# Altium schematic sheet Amp_op4.SchDoc(Amp_op4)
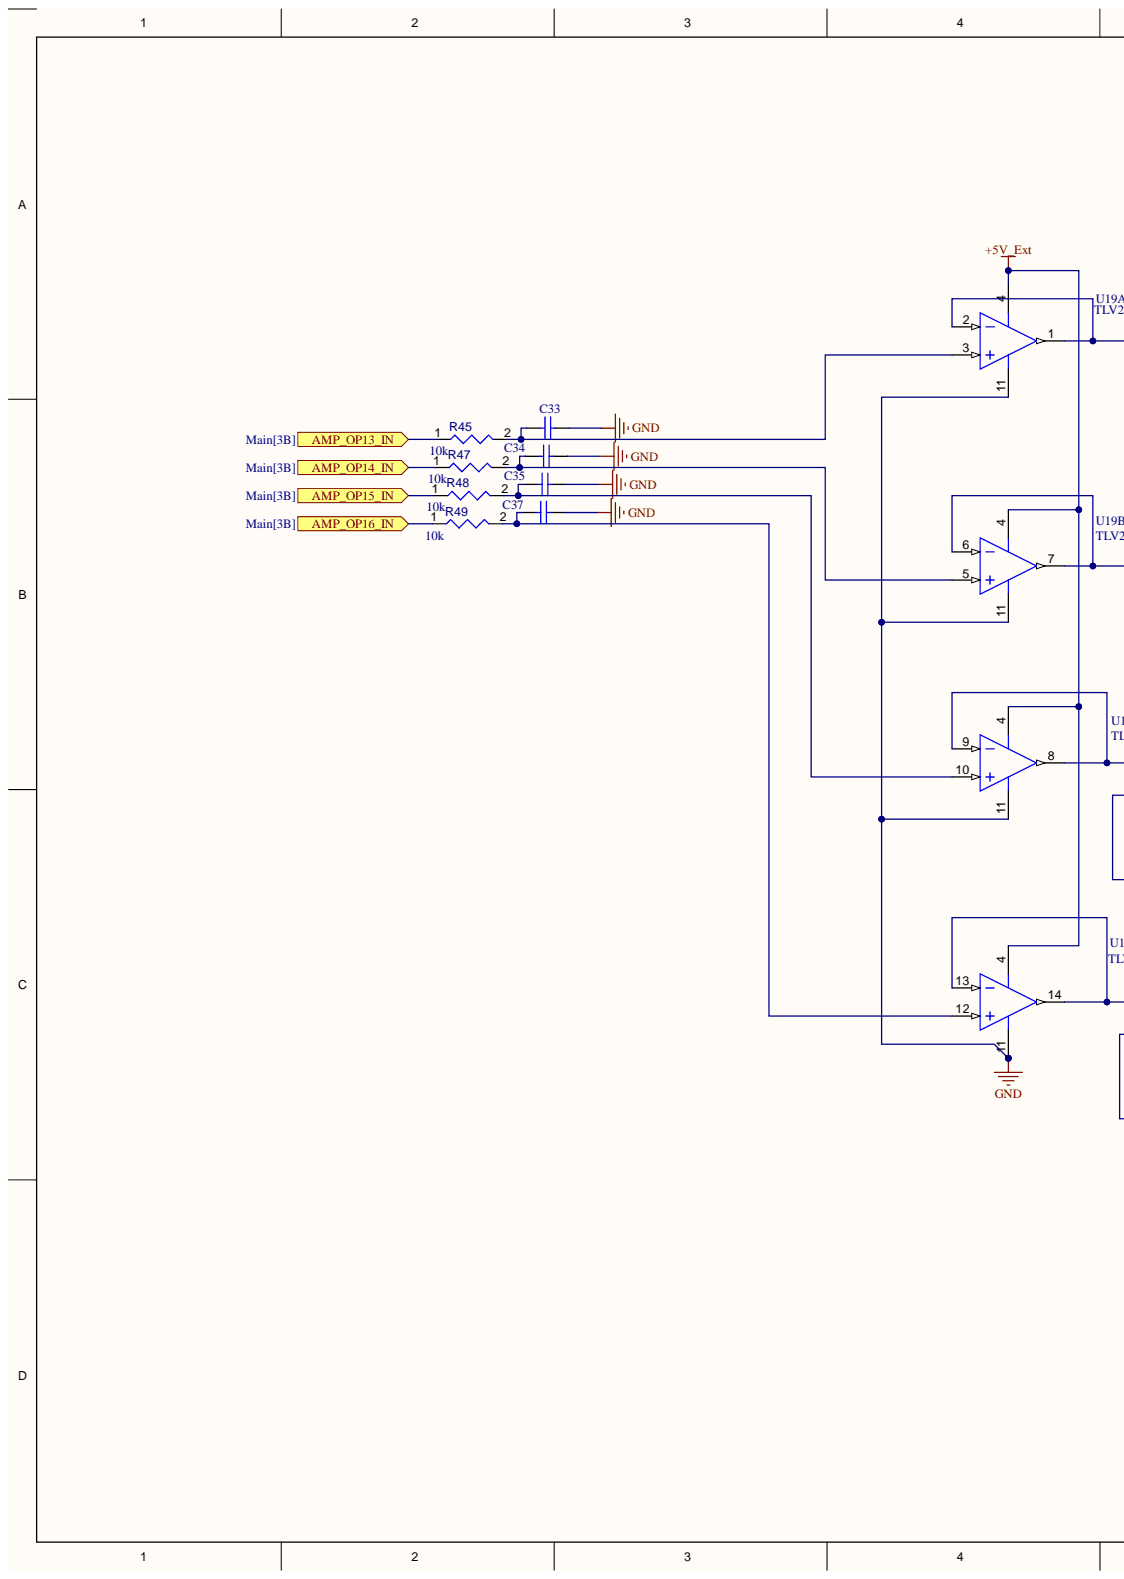
[diagram p0: Amp_op4.SchDoc, left]
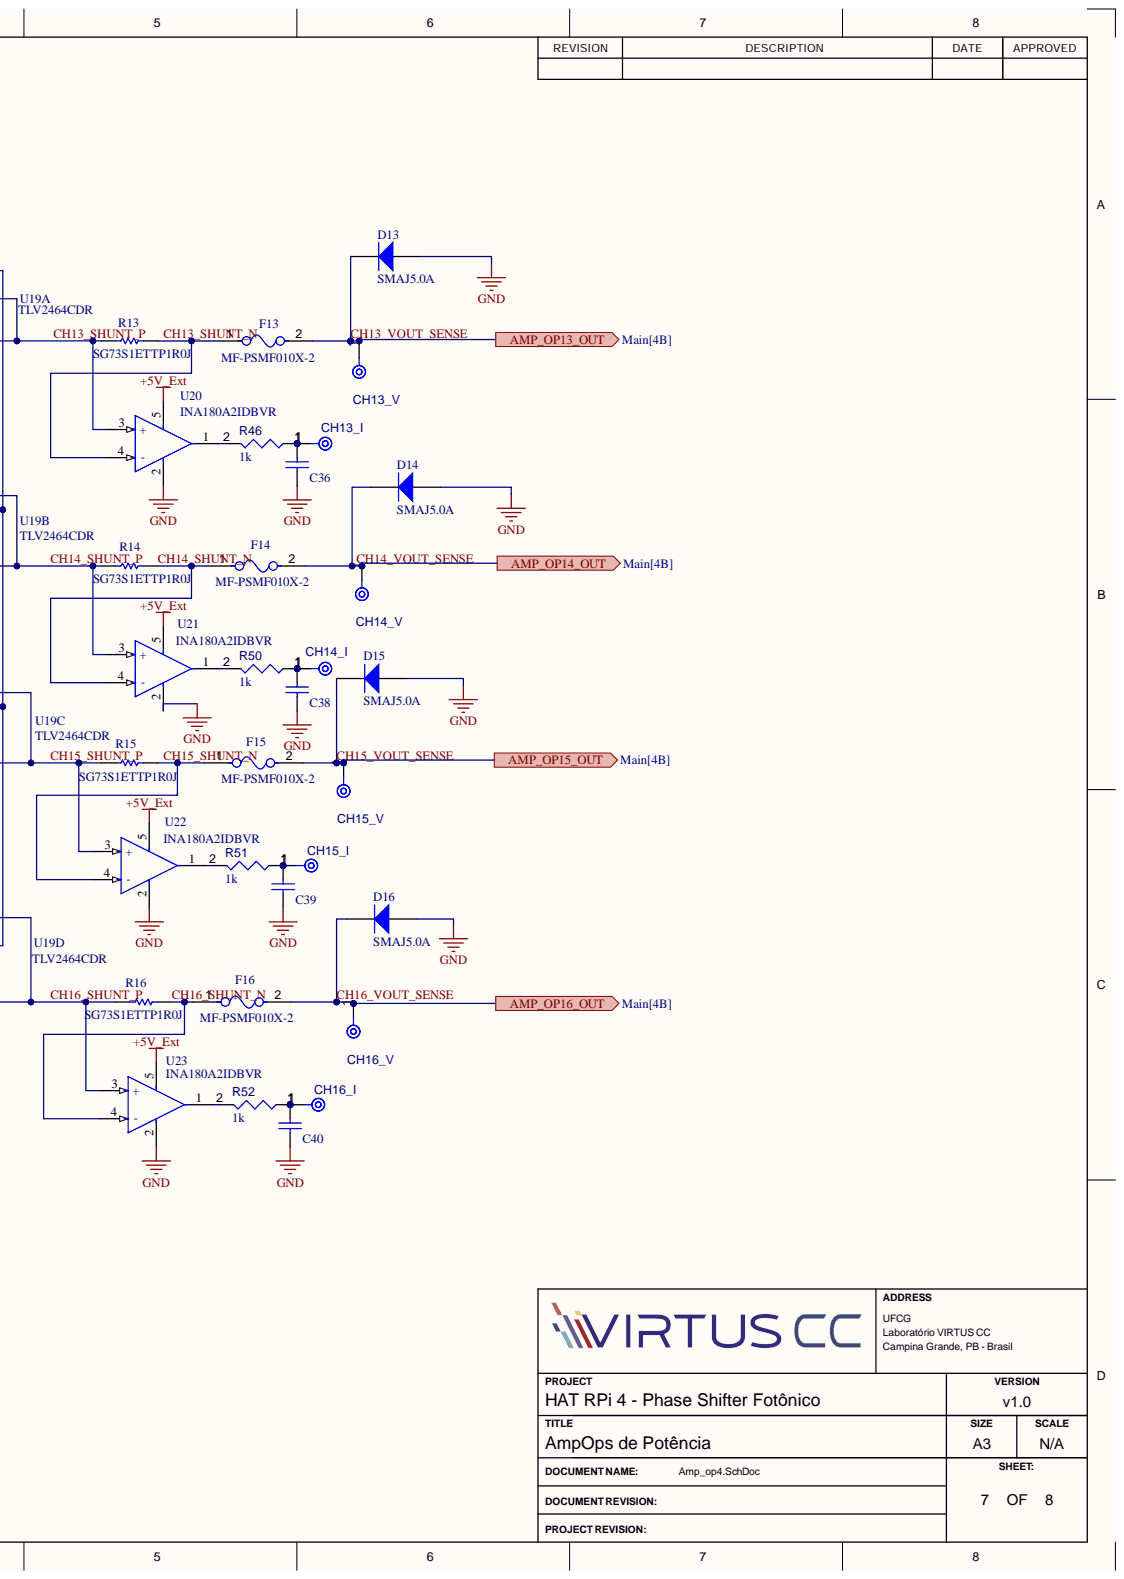
[diagram p1: Amp_op4.SchDoc, right]

## Parts
C33  VJ0603Y104MXJCW1BC  [CAPC1608X95X40NL15T15]  (value not drawn)
C34  VJ0603Y104MXJCW1BC  [CAPC1608X95X40NL15T15]  (value not drawn)
C35  VJ0603Y104MXJCW1BC  [CAPC1608X95X40NL15T15]  (value not drawn)
C36  VJ0603Y104MXJCW1BC  [CAPC1608X95X40NL15T15]  (value not drawn)
C37  VJ0603Y104MXJCW1BC  [CAPC1608X95X40NL15T15]  (value not drawn)
C38  VJ0603Y104MXJCW1BC  [CAPC1608X95X40NL15T15]  (value not drawn)
C39  VJ0603Y104MXJCW1BC  [CAPC1608X95X40NL15T15]  (value not drawn)
C40  VJ0603Y104MXJCW1BC  [CAPC1608X95X40NL15T15]  (value not drawn)
CH13_I  TPAD6R  [TP06R]  (value not drawn)  (no BOM)
CH13_V  TPAD6R  [TP06R]  (value not drawn)  (no BOM)
CH14_I  TPAD6R  [TP06R]  (value not drawn)  (no BOM)
CH14_V  TPAD6R  [TP06R]  (value not drawn)  (no BOM)
CH15_I  TPAD6R  [TP06R]  (value not drawn)  (no BOM)
CH15_V  TPAD6R  [TP06R]  (value not drawn)  (no BOM)
CH16_I  TPAD6R  [TP06R]  (value not drawn)  (no BOM)
CH16_V  TPAD6R  [TP06R]  (value not drawn)  (no BOM)
D13  SMAJ5.0A  [BOUR-SMA-2_V]
D14  SMAJ5.0A  [BOUR-SMA-2_V]
D15  SMAJ5.0A  [BOUR-SMA-2_V]
D16  SMAJ5.0A  [BOUR-SMA-2_V]
F13  MF-PSMF010X-2  [FP-MF-PSMF-MFG]
F14  MF-PSMF010X-2  [FP-MF-PSMF-MFG]
F15  MF-PSMF010X-2  [FP-MF-PSMF-MFG]
F16  MF-PSMF010X-2  [FP-MF-PSMF-MFG]
R13  SG73S1ETTP1R0J  [RESC1005X40X25LL10T10]
R14  SG73S1ETTP1R0J  [RESC1005X40X25LL10T10]
R15  SG73S1ETTP1R0J  [RESC1005X40X25LL10T10]
R16  SG73S1ETTP1R0J  [RESC1005X40X25LL10T10]
R45  value 10k  [RESC0603(1608)_L]  (hidden comment: Resistor 10k +/-1% 0603 100 mW)
R46  value 1k  [RESC0603(1608)_L]  (hidden comment: Resistor 1k +/-1% 0603 100 mW)
R47  value 10k  [RESC0603(1608)_L]  (hidden comment: Resistor 10k +/-1% 0603 100 mW)
R48  value 10k  [RESC0603(1608)_L]  (hidden comment: Resistor 10k +/-1% 0603 100 mW)
R49  value 10k  [RESC0603(1608)_L]  (hidden comment: Resistor 10k +/-1% 0603 100 mW)
R50  value 1k  [RESC0603(1608)_L]  (hidden comment: Resistor 1k +/-1% 0603 100 mW)
R51  value 1k  [RESC0603(1608)_L]  (hidden comment: Resistor 1k +/-1% 0603 100 mW)
R52  value 1k  [RESC0603(1608)_L]  (hidden comment: Resistor 1k +/-1% 0603 100 mW)
U19  TLV2464CDR  [D0014A_N]  (parts A,B,C,D)
U20  INA180A2IDBVR  [FP-DBV0005A-MFG]
U21  INA180A2IDBVR  [FP-DBV0005A-MFG]
U22  INA180A2IDBVR  [FP-DBV0005A-MFG]
U23  INA180A2IDBVR  [FP-DBV0005A-MFG]

## Ports
AMP_OP13_IN, AMP_OP13_OUT, AMP_OP14_IN, AMP_OP14_OUT, AMP_OP15_IN, AMP_OP15_OUT, AMP_OP16_IN, AMP_OP16_OUT

## Nets
+5V_Ext: U19.4 U20.5 U21.5 U22.5 U23.5
GND: C33.2 C34.2 C35.2 C36.2 C37.2 C38.2 C39.2 C40.2 D13.1 D14.1 D15.1 D16.1 U19.11 U20.2 U21.2 U22.2 U23.2
AMP_OP13_IN: R45.1 ; port AMP_OP13_IN
AMP_OP14_IN: R47.1 ; port AMP_OP14_IN
AMP_OP15_IN: R48.1 ; port AMP_OP15_IN
AMP_OP16_IN: R49.1 ; port AMP_OP16_IN
CH13_SHUNT_N: F13.1 R13.2 U20.4(-)
CH13_SHUNT_P: R13.1 U19.1 U19.2 U20.3(+)
CH13_VOUT_SENSE: CH13_V.1 D13.2 F13.2 ; port AMP_OP13_OUT
CH14_SHUNT_N: F14.1 R14.2 U21.4(-)
CH14_SHUNT_P: R14.1 U19.6 U19.7 U21.3(+)
CH14_VOUT_SENSE: CH14_V.1 D14.2 F14.2 ; port AMP_OP14_OUT
CH15_SHUNT_N: F15.1 R15.2 U22.4(-)
CH15_SHUNT_P: R15.1 U19.8 U19.9 U22.3(+)
CH15_VOUT_SENSE: CH15_V.1 D15.2 F15.2 ; port AMP_OP15_OUT
CH16_SHUNT_N: F16.1 R16.2 U23.4(-)
CH16_SHUNT_P: R16.1 U19.13 U19.14 U23.3(+)
CH16_VOUT_SENSE: CH16_V.1 D16.2 F16.2 ; port AMP_OP16_OUT
NetC33_1: C33.1 R45.2 U19.3
NetC34_1: C34.1 R47.2 U19.5
NetC35_1: C35.1 R48.2 U19.10
NetC36_1: C36.1 CH13_I.1 R46.1
NetC37_1: C37.1 R49.2 U19.12
NetC38_1: C38.1 CH14_I.1 R50.1
NetC39_1: C39.1 CH15_I.1 R51.1
NetC40_1: C40.1 CH16_I.1 R52.1
NetR46_2: R46.2 U20.1
NetR50_2: R50.2 U21.1
NetR51_2: R51.2 U22.1
NetR52_2: R52.2 U23.1

## Library data of the parts
C33-C40 = CMP-2006-00058-1: Manufacturer: Vitramon; Component Kind: Standard; Library Name: Altium Content Vault; Part Number: VJ0603Y104MXJCW1BC
CH13_I CH13_V CH14_I CH14_V CH15_I CH15_V CH16_I CH16_V = CMP-00028-00002-2: 0.6mm round; Component Type: Mechanical; Pins: 1; Library Name: PCEIOT.SCHLIB
D13-D16 = CMP-2000-07090-1: SMAJ Transient Voltage Suppressor Diode Series, 3.5 V, -55 to 150 degC, 2-Pin SMA, RoHS, Tape and Reel; Manufacturer: Bourns; Catalog Drawings: SMAJSeriesFootprintSMAJ,SMBJSeries; Current - Peak Pulse (10/1000µs): 43.5A; EDA / CAD Models: DownloadfromAcceleratedDesigns; Featured Product: SMAJ/SMBJ/SMCJ/SMLJDiscreteTVSDiodes; Online Catalog: SMAJSeries; Other Names: SMAJ5.0ABDKR; Power - Peak Pulse: 400W; Power Line Protection: No; Type: Zener; Unidirectional Channels: 1; Voltage - Breakdown (Min): 6.4V; Voltage - Clamping (Max) @ Ipp: 9.2V; Voltage - Reverse Standoff (Typ): 5V; Applications: GeneralPurpose; Bidirectional Channels: -; Capacitance @ Frequency: -; Category: CircuitProtection; Component Kind: Standard; Datasheet URL: https://datasheet.ciiva.com/25624/0900766b8112213b-25624680.pdf; Datasheet Version: 08/2012; Family: TVS-Diodes; Library Name: Altium Content Vault; Manufacturer URL: http://www.bourns.com/; Mounting Technology: SurfaceMount; Mounting Type: SurfaceMount; Operating Temperature: -55°C~150°C(TJ); Package / Case: DO-214AC,SMA; Package Description: 2-Pin Surface Mount Device, Body 4.315 x 2.605 mm; Package Reference: SMA-2; Packaging: Digi-Reel®; RoHS Information: SMAJSeriesMaterialDeclaration; Series: SMAJ; Standard Package: 1; Supplier Device Package: SMA(DO-214AC)
F13-F16 = CMP-07400-000017-1: PTC RESET FUSE 15V 100MA 0805; Manufacturer: Bourns Inc.; Current Rating: 40A; Hold Current: 0.1A; Resistance: 1-7.5Ω; Trip Current: 0.3A; Trip Time: 1.5s; Value: 1-7.5Ω; Ambient Temperature Range High: +85°C; Ambient Temperature Range Low: -40°C; Automotive: No; Category: Fuse; Component Kind: Standard; ComponentLink2Description: Datasheet; ComponentLink2URL: https://www.bourns.com/docs/Product-Datasheets/mfpsmf.pdf; Device Class L1: Circuit Protection; Device Class L2: PTC Resettable Fuses; Device Class L3: unset; Digikey Description: PTC RESET FUSE 15V 100MA 0805; Height: 0.85mm; Ipc Land Pattern Name: CAPC215135X67; Lead Free: Yes; Library Name: Altium Content Vault; Manufacturer Part Number: MF-PSMF010X-2; Mouser Description: Resettable Fuses - PPTC 0.10A 15V 1ohm; Octopart: https://octopart.com/mf-psmf010x-2-bourns-7483441; Package: MF-PSMF; RoHS: TRUE; Temperature: -40°C to +85°C; Temperature Range High: +85°C; Temperature Range Low: -40°C; Voltage Rating: 15V
R13-R16 = CMP-2002-04124-1: Manufacturer: KOA Speer; Component Kind: Standard; Library Name: Altium Content Vault; Part Number: SG73S1ETTP1R0J
R45 R47-R49 = CMP-009-00017-7: Chip Resistor, 10 KOhm, +/- 1%, 01 W, -55 to 155 degC, 0603 (1608 Metric); Manufacturer: Vishay; Manufacturer Part Number: CRCW060310K0FKEA; Power: 100mW; Voltage Rating: 50V; Case/Package: 0603; Component Kind: Standard; Component Type: Resistors; Max Operating Temperature: 155°C; Min Operating Temperature: -55°C; Mounting Technology: SM; Pins: 2; RoHS Compliant: True; Tolerance: 1%; Library Name: PCEIOT.SCHLIB
R46 R50-R52 = CMP-009-00014-6: Chip Resistor, 1 KOhm, +/-1%, 0.1 W, -55 to 155 degC, 0603 (1608 Metric); Manufacturer: Yageo; Manufacturer Part Number: RC0603FR-131KL; Power: 100mW; Tolerance: 1%; Voltage Rating: 50V; Case/Package: 0603; Component Kind: Standard; Component Type: Resistors; Max Operating Temperature: 155°C; Min Operating Temperature: -55°C; Mounting Technology: SM; Pins: 2; RoHS Compliant: True; Library Name: PCEIOT.SCHLIB
U19 = CMP-0271-00006-3: Quad Low Power, Rail-to-Rail Input / Output Operational Amplifier, 2.7 to 6 V, 0 to 70 degC, 14-pin SOIC (D14), Green (RoHS & no Sb/Br); Manufacturer: Texas Instruments; CMRR(Min)(dB): 66; IIB(Max)(pA): 14000; Iq per channel(Max)(mA): 0.575; Number of Channels: 4; Offset Drift(Typ)(uV/C): 2; Slew Rate(Typ)(V/us): 1.6; Sub Family: High Output Current Amplifier (>=50mA), Low Supply Voltage Amplifier (<=2.7V), Precision Amplifier; Total Supply Voltage(Max)(+5V is 5, +/-5V is 10): 6; Total Supply Voltage(Min)(+5V is 5, +/-5V is 10): 2.7; Vn at 1kHz(Typ)(nV/rtHz): 11; Code_JEDEC: MS-012; Component Kind: Standard; ComponentLink1Description: Manufacturer URL; ComponentLink1URL: http://www.ti.com/; ComponentLink2Description: Datasheet; ComponentLink2URL: http://www.ti.com/general/docs/lit/getliterature.tsp?genericPartNumber=TLV2464&fileType=pdf; ComponentLink3Description: Package Specification; ComponentLink3URL: http://www.ti.com/lit/ml/mpds177g/mpds177g.pdf; DatasheetVersion: SLOS220J; Eco-Plan: Green (RoHS & no Sb/Br); Library Name: Altium Content Vault; Mounting Technology: Surface Mount; PackageDescription: 14-Pin Plastic Small Outline, Body 8.65 x 3.9 mm, Pitch 1.27 mm; PackageReference: D0014A; PackageVersion: 4040047-5/M, 06/2011; PartNumber: TLV2464CDR; Vio (25C)(Max)(mV): 2
U20-U23 = CMP-04895-000287-1: IC CURR SENSE 1 CIRCUIT SOT23-5; -3db Bandwidth: 350kHz; Input Bias Current: 100nA; Input Offset Voltage (Vos): 100uV; Number of Circuits: 1; Operating Supply Current: 260uA; Slew Rate: 2V/µs; Case/Package: SOT-23-5; Component Kind: Standard; Library Name: Altium Content Vault; Max Operating Temperature: 125°C; Min Operating Temperature: -40°C; Mount: Surface Mount; Packaging: Tape and Reel; RoHS Compliant: Yes
